# Altium schematic sheet lv_signal_temp.SchDoc(lv_signal_temp)
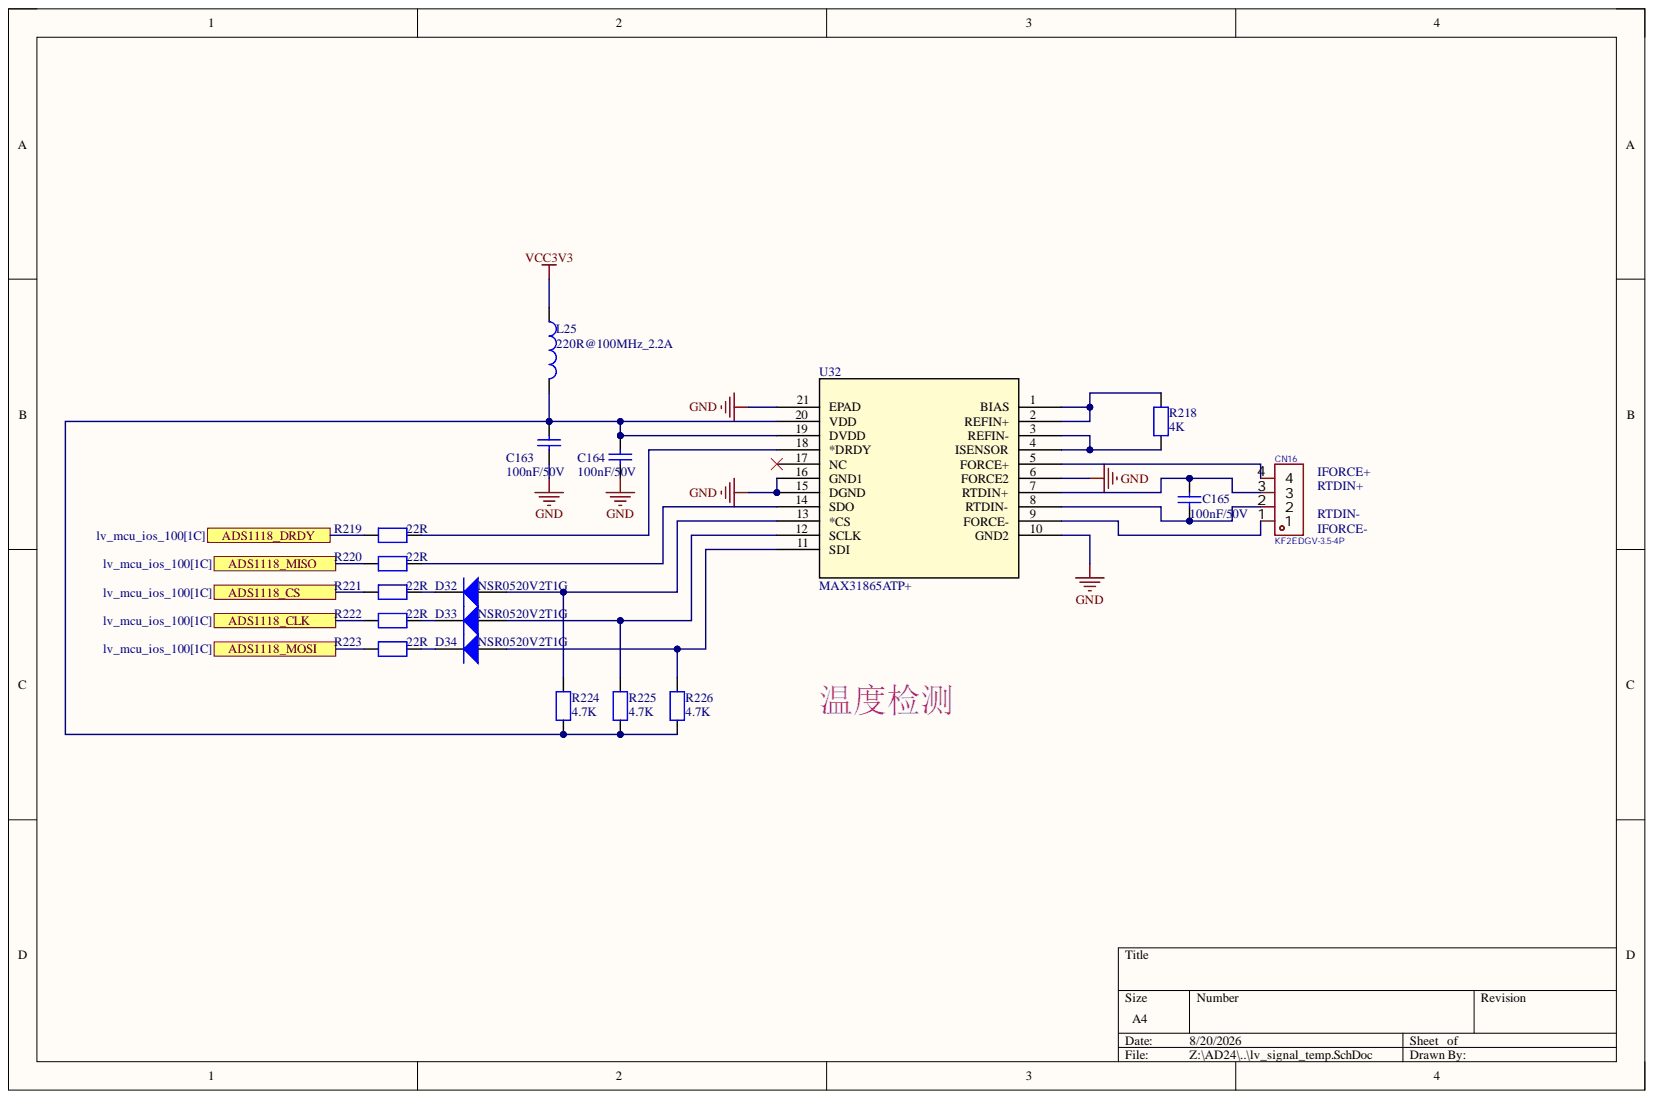
[diagram p0: lv_signal_temp.SchDoc]

## Parts
C163  100nF/50V  [C0402]
C164  100nF/50V  [C0402]
C165  100nF/50V  [C0402]
CN16  KF2EDGV-3.5-4P  [CONN-TH_4P-P3.50_KF2EDGV-3.5-4P]
D32  NSR0520V2T1G  [SOD-523F_L1.2-W0.8-LS1.7-RD]
D33  NSR0520V2T1G  [SOD-523F_L1.2-W0.8-LS1.7-RD]
D34  NSR0520V2T1G  [SOD-523F_L1.2-W0.8-LS1.7-RD]
L25  220R@100MHz_2.2A  [L0603]
R218  4K  [0805]
R219  22R  [R0402]
R220  22R  [R0402]
R221  22R  [R0402]
R222  22R  [R0402]
R223  22R  [R0402]
R224  4.7K  [R0402]
R225  4.7K  [R0402]
R226  4.7K  [R0402]
U32  MAX31865ATP+  [21-0140_T2055+5_MXM]

## Ports
ADS1118_CLK, ADS1118_CS, ADS1118_DRDY, ADS1118_MISO, ADS1118_MOSI

## Nets
GND: C163.2 C164.2 U32.6(FORCE2) U32.10(GND2) U32.15(DGND) U32.16(GND1) U32.21(EPAD)
VCC3V3: L25.2
ADS1118_CLK: R222.2 ; port ADS1118_CLK
ADS1118_CS: R221.2 ; port ADS1118_CS
ADS1118_DRDY: R219.2 ; port ADS1118_DRDY
ADS1118_MISO: R220.2 ; port ADS1118_MISO
ADS1118_MOSI: R223.2 ; port ADS1118_MOSI
NetC163_1: C163.1 C164.1 L25.1 R224.2 R225.2 R226.2 U32.19(DVDD) U32.20(VDD)
NetC165_1: C165.1 CN16.3 U32.7(RTDIN+)
NetC165_2: C165.2 CN16.2 U32.8(RTDIN-)
NetCN16_1: CN16.1 U32.9(FORCE-)
NetCN16_4: CN16.4 U32.5(FORCE+)
NetD32_1: D32.1 R221.1
NetD32_2: D32.2 R224.1 U32.13(*CS)
NetD33_1: D33.1 R222.1
NetD33_2: D33.2 R225.1 U32.12(SCLK)
NetD34_1: D34.1 R223.1
NetD34_2: D34.2 R226.1 U32.11(SDI)
NetR218_1: R218.1 U32.1(BIAS) U32.2(REFIN+)
NetR218_2: R218.2 U32.3(REFIN-) U32.4(ISENSOR)
NetR219_1: R219.1 U32.18(*DRDY)
NetR220_1: R220.1 U32.14(SDO)

Not connected: U32 17(NC)

## Library data of the parts
C163 C164 = Capacitor: 50V, 5%, X7R; Manufacturer: muRata; Manufacturer_Part_Number: GRM155R71H104KE14D; Component Kind: Standard; ComponentLink1Description: Datasheet; ComponentLink1URL: http://tunmcdsvn.tun.st.com/Component_Datasheet_Library/Trunk/Capacitors/Yageo_Capacitor_NP0_16V-to-50V; Library Name: HiPin_Library_AD.IntLib
C165 = Capacitor_1: 50V, X7R, 10%; Manufacturer: muRata; Manufacturer_Part_Number: GRM188R71H104KA93D; Component Kind: Standard; ComponentLink1Description: Yageo_Capacitor_X5R-series.pdf; ComponentLink1URL: http://tunmcdsvn.tun.st.com/Component_Datasheet_Library/Trunk/Capacitors/Yageo_Multilayer_capacitor_X5R_series_100pF_to_100uF; Library Name: HiPin_Library_AD.IntLib
CN16 = KF2EDGV-3.5-4P: 3.5mm , 4Pin; Manufacturer: KEFA(科发); Add into BOM: yes; Connector Type: 板端/插座-闭口; Current Rating (Max): 10A; Number of PINs Per Row: 4; Structure: 1x4P; Voltage Rating (Max): 300V; Color: 绿色; Component Kind: Standard; Connection Type: -; Contact Material: 铜合金; Contact Plating: 锡; Convert to PCB: yes; Datasheet: https://atta.szlcsc.com/upload/public/pdf/source/20230331/973DE48A1FC0C5DCFB383F3EF6D70D72.pdf; Device: KF2EDGV-3.5-4P; fixed form: -; JLCPCB Part Class: Extended Part; LCSC Part Name: 1x4P 3.5mm 排数:1 每排P数:4; Library Name: HiPin_Library_AD.IntLib; Manufacturer Part: KF2EDGV-3.5-4P; Mounting Style: 直针; Number of Pins: 4P; Number of Rows: 1; Operating Temperature Range: -40℃~+105℃; Outlet Direction (Vertical Basis): -; PCB3D: CONN-TH_4P-P3.50_KF2EDGV-3.5-4P; Pitch: 3.5mm; Soldering Temperature(Max): -; Supplier: LCSC; Supplier Footprint: 插件,P=3.5mm; Supplier Part: C441323; Symbol: KF2EDGV-3.5-4P; Wire Gauge - AWG: -; Wire Gauge - mm2: -
D32-D34 = 1N4148: Component Kind: Standard; Library Name: HiPin_Library_AD.IntLib
L25 = MCD-00047: SMD Chip ferrite bead, 220R@100MHz +/-25%,2.2A, 50mohm; Manufacturer: Sunlord; Manufacturer_Part_Number: UPZ1608U221-2R2TF; Database Table Name: MCD_PARTS$; Component Kind: Standard; ComponentLink1Description: Datasheet; ComponentLink1URL: http://tunmcdsvn.tun.st.com/Component_Datasheet_Library/Trunk/Inductors/TaiTech_Bead_FCM1608_series; Internal_Part_Number: MCD-00047; Library Name: MCD_PARTS_database.DbLib
R218 = RES: 0.1%, 25ppm; Component Kind: Standard; Library Name: HiPin_Library_AD.IntLib
R219-R226 = RES: 1%; Component Kind: Standard; Library Name: HiPin_Library_AD.IntLib
U32 = MAX31865ATP+: RTD-to-Digital Converter; Manufacturer_Name: Analog Devices Inc; Component Kind: Standard; Library Name: HiPin_Library_AD.IntLib; Manufacturer_Part_Number: MAX31865ATP+; RefDes: RefDes; Type: Type; Copyright: Copyright (C) 2025 Ultra Librarian. All rights reserved.
